# Altium schematic sheet PressureSensorCircuit.SchDoc
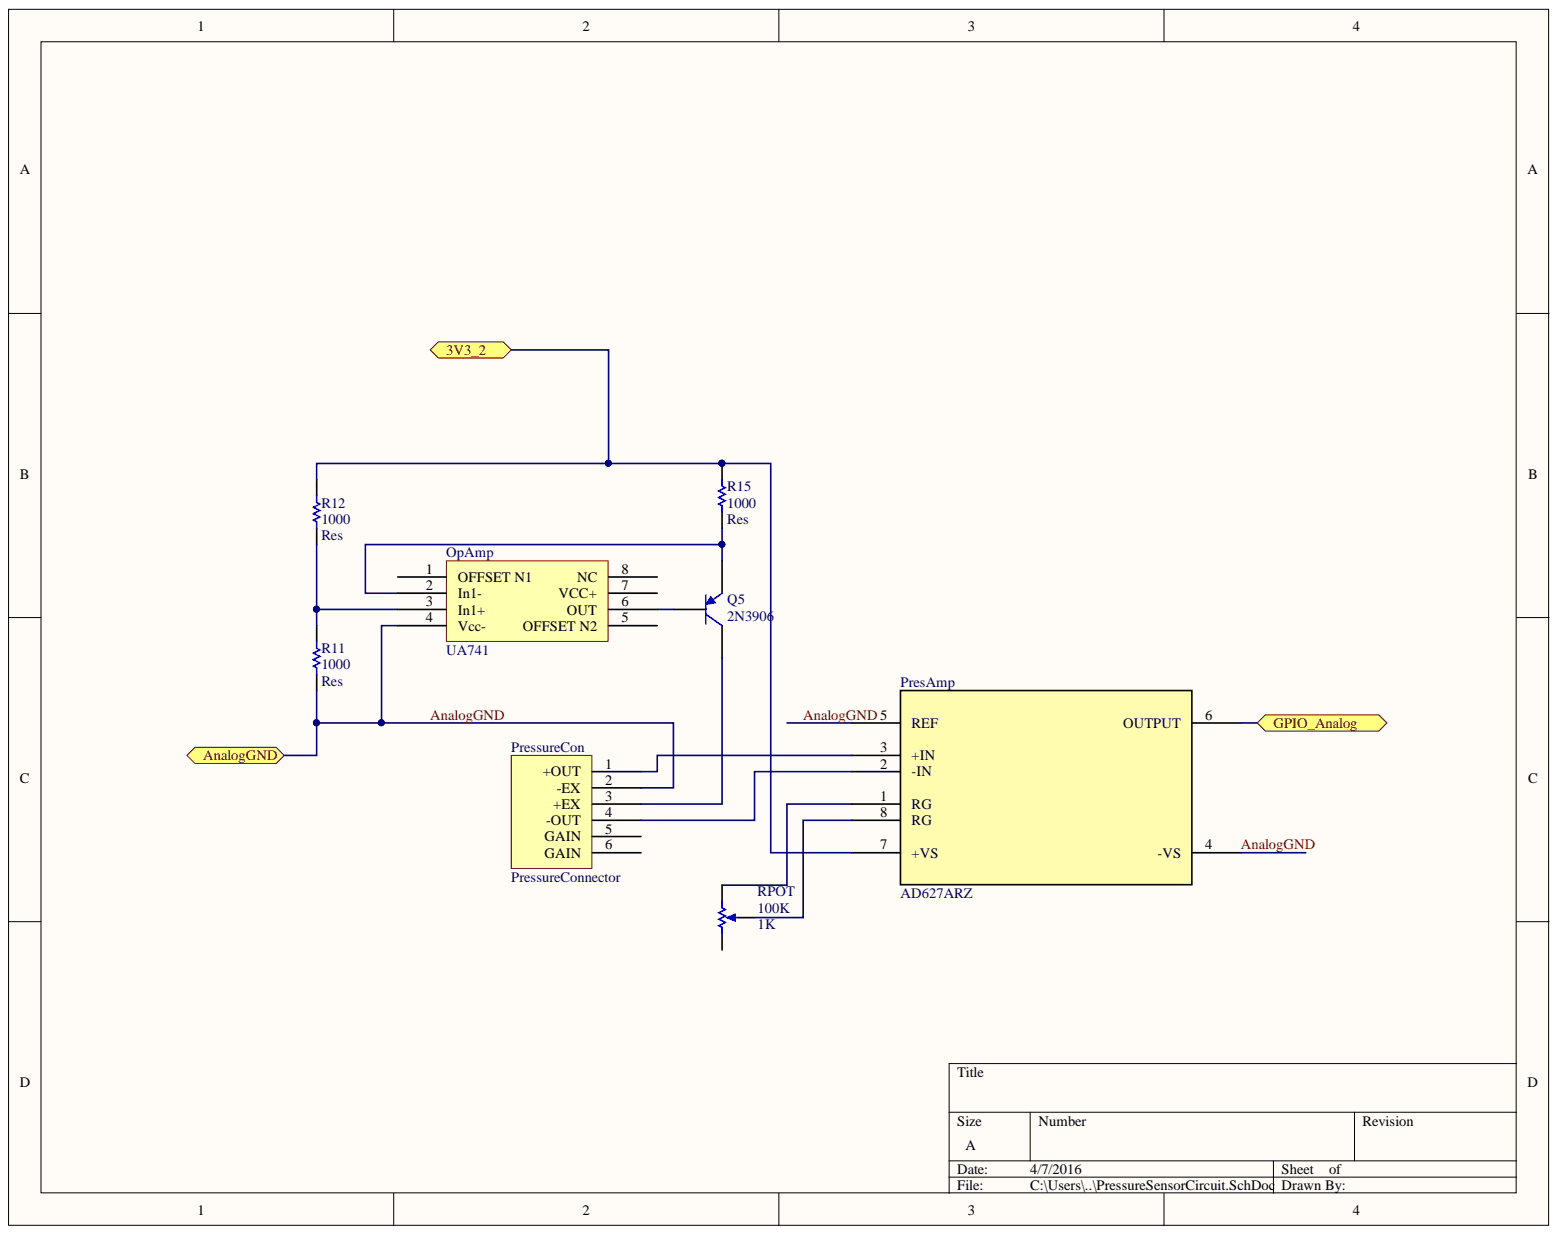
[diagram p0: PressureSensorCircuit.SchDoc]

## Parts
OpAmp  UA741  [UA741]
PresAmp  AD627ARZ  [R_8]
PressureCon  PressureConnector  [Pressure Connector]
Q5  2N3906  [TO-92A]
R11  1000  value Res  [AXIAL-0.3]
R12  1000  value Res  [AXIAL-0.3]
R15  1000  value Res  [AXIAL-0.3]
RPOT  100K  value 1K  [VR5]

## Ports
3V3_2, ANALOGGND, GPIO_ANALOG

## Nets
3V3_2: PresAmp.7(+VS) R12.1 R15.1 ; port 3V3_2
ANALOGGND: OpAmp.4(Vcc-) PresAmp.4(-VS) PresAmp.5(REF) PressureCon.2(-EX) R11.2 ; port ANALOGGND
NetOpAmp_2: OpAmp.2(In1-) Q5.1 R15.2
NetOpAmp_3: OpAmp.3(In1+) R11.1 R12.2
NetOpAmp_6: OpAmp.6(OUT) Q5.2
NetPresAmp_1: PresAmp.1(RG) RPOT.2
NetPresAmp_2: PresAmp.2(-IN) PressureCon.4(-OUT)
NetPresAmp_3: PresAmp.3(+IN) PressureCon.1(+OUT)
NetPresAmp_6: PresAmp.6(OUTPUT) ; port GPIO_ANALOG
NetPresAmp_8: PresAmp.8(RG) RPOT.3
NetPressureCon_3: PressureCon.3(+EX) Q5.3

Not connected: OpAmp 1(OFFSET_N1) 5(OFFSET_N2) 7(VCC+) 8(NC); PressureCon 5(GAIN) 6(GAIN); RPOT 1

## Library data of the parts
PresAmp = AD627ARZ: Imported; Component Kind: Standard; Library Name: 2016-04-06_02-20-10.SchLib; Manufacturer_Part_Number: AD627ARZ; RefDes: Refdes; Type: Type; Value: Value; Vendor: Vendor
PressureCon = PressureConnector: Molex 70553-0005; Component Kind: Standard; Library Name: UmbilicalBoardV2.SCHLIB
Q5 = 2N3906: PNP General Purpose Amplifier; Component Kind: Standard; ComponentLink1Description: Manufacturer Link; ComponentLink1URL: http://www.fairchildsemi.com/; ComponentLink2Description: Datasheet; ComponentLink2URL: http://www.fairchildsemi.com/ds/2N%2F2N3906.pdf; DatasheetVersion: 1997; LatestRevisionDate: 15-Jan-2003; LatestRevisionNote: PCB Footprint 'BCY-W3/D4.7' replaced; Library Name: Miscellaneous Devices.IntLib; PackageDescription: TO, Flat Index; 3 In-Line, Axial Leads; Body Dia. 4.5mm; Leads 0.51 x 0.48 mm (max); PackageReference: TO-92A; PackageVersion: Sep-1998; Published: 8-Jun-2000; Signal Integrity: 2N3906; Simulation: 2N3906; Publisher: Altium Limited
R11 R12 R15 = Res1: Resistor; Component Kind: Standard; LatestRevisionDate: 17-Jul-2002; LatestRevisionNote: Re-released for DXP Platform.; Library Name: Miscellaneous Devices.IntLib; PackageDescription: Axial Device, Thru-Hole; 2 Leads; 0.3 in Pin Spacing; PackageReference: AXIAL-0.3; Published: 8-Jun-2000; Signal Integrity: Res; Simulation: RESISTOR; Publisher: Altium Limited
RPOT = RPot: Potentiometer; Set Position: 0.5; Sim Note: Enter the Resistance value and the set point into parameter fields.; Component Kind: Standard; LatestRevisionDate: 17-Jul-2002; LatestRevisionNote: Re-released for DXP Platform.; Library Name: Miscellaneous Devices.IntLib; PackageDescription: Variable Resistor, Thru-Hole; 3 Leads; PackageReference: VR5; Published: 8-Jun-2000; Simulation: POT; Publisher: Altium Limited
OpAmp = UA741: Component Kind: Standard; Library Name: UmbilicalBoardV2.SCHLIB
